# Altium schematic sheet Sheet1.SchDoc
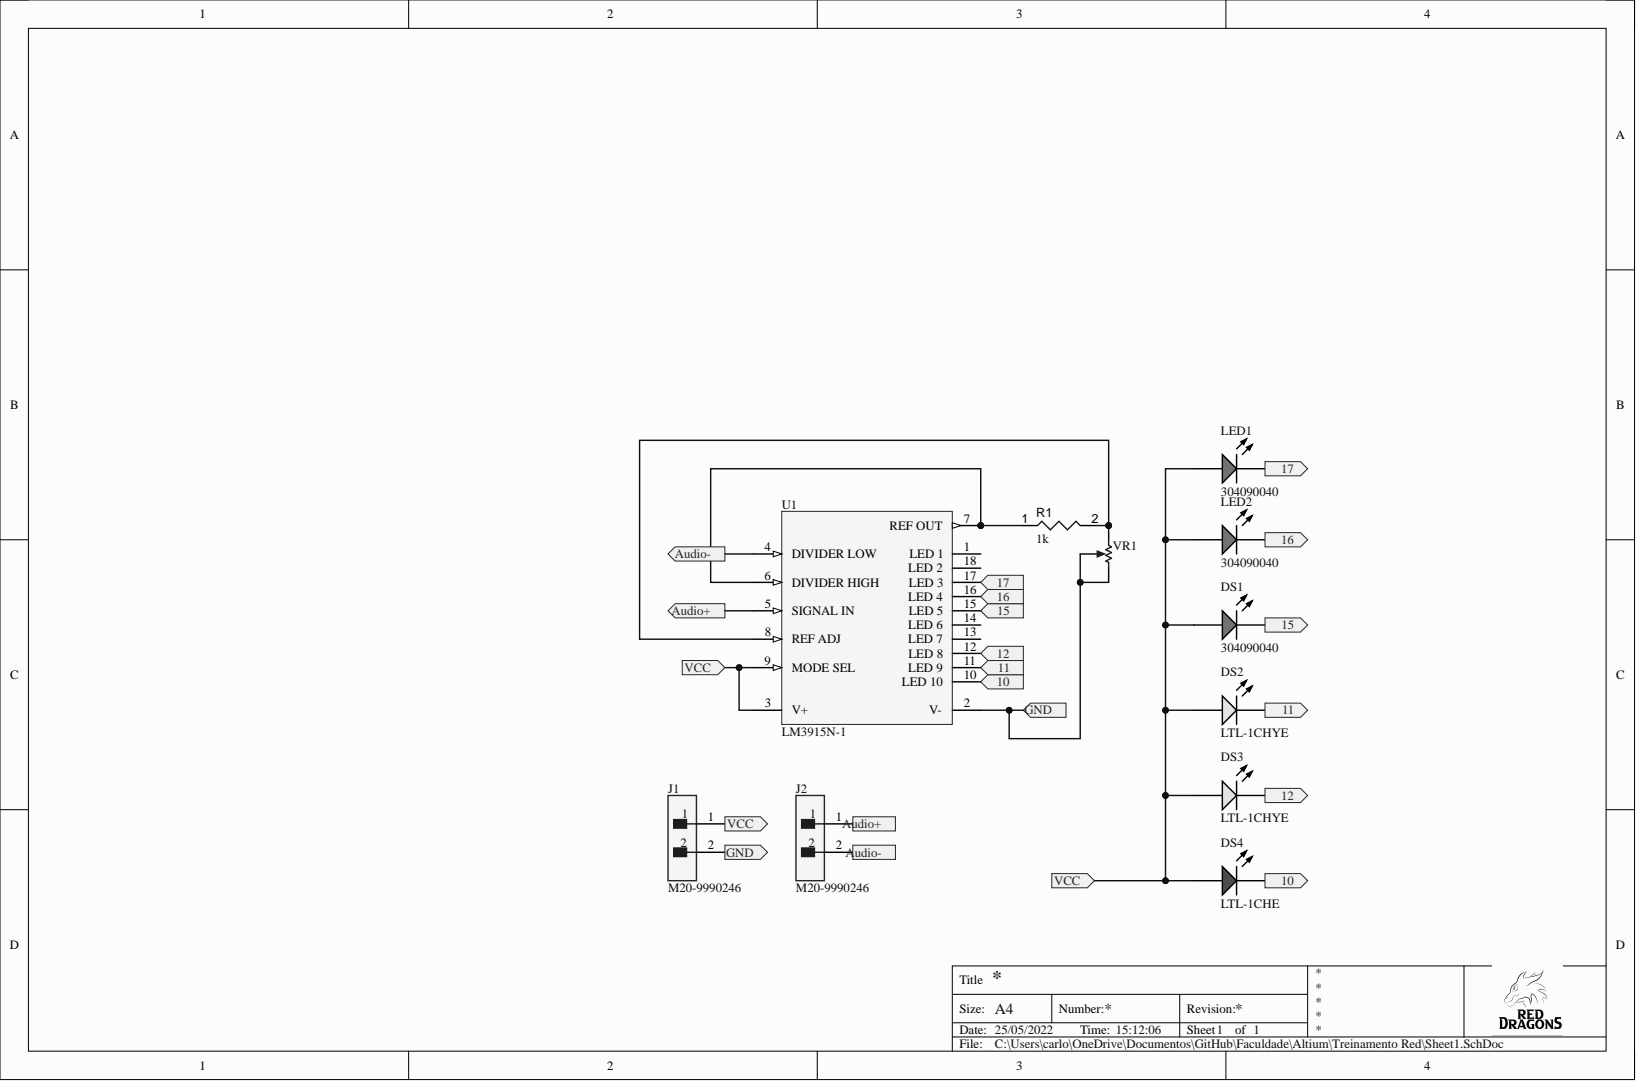
[diagram p0: Sheet1.SchDoc]

## Parts
DS1  304090040  [LED-2P-2.28-3MM]
DS2  LTL-1CHYE  [LTST-LTL-1CHYE]
DS3  LTL-1CHYE  [LTST-LTL-1CHYE]
DS4  LTL-1CHE  [INDPR60-254-520X380X650]
J1  M20-9990246  [FP-M20-9990246-MFG]
J2  M20-9990246  [FP-M20-9990246-MFG]
LED1  304090040  [LED-2P-2.28-3MM]
LED2  304090040  [LED-2P-2.28-3MM]
R1  value 1k  [RESA60-630X240]  (hidden comment: MFR-25FBF52-1K)
U1  LM3915N-1  [NFK0018A]
VR1  3362P-1-103LF  [BOUR-3362P]  (value not drawn)

## Ports
10, 11, 12, 15, 16, 17, Audio+, Audio-, GND, VCC

## Nets
10: DS4.2 U1.10(LED_10) ; port 10
11: DS2.2 U1.11(LED_9) ; port 11
12: DS3.2 U1.12(LED_8) ; port 12
15: DS1.2 U1.15(LED_5) ; port 15
16: LED2.2 U1.16(LED_4) ; port 16
17: LED1.2 U1.17(LED_3) ; port 17
GND: J1.2 U1.2(V-) VR1.2 VR1.3 ; port GND
VCC: DS1.1 DS2.1 DS3.1 DS4.1 J1.1 LED1.1 LED2.1 U1.3(V+) U1.9(MODE_SEL) ; port VCC
NetJ2_1: J2.1 U1.5(SIGNAL_IN)
NetJ2_2: J2.2 U1.4(DIVIDER_LOW)
NetR1_1: R1.1 U1.6(DIVIDER_HIGH) U1.7(REF_OUT)
NetR1_2: R1.2 U1.8(REF_ADJ) VR1.1

Not connected: U1 1(LED_1) 13(LED_7) 14(LED_6) 18(LED_2)

## Library data of the parts
DS1 LED1 LED2 = CMP-007-00006-2: Green LED, 80 mW, 20 mA, -25 to 85 degC, 2-Pin THD; Manufacturer: Shenzhen CGX; Case/Package: 304090040; Component Kind: Standard; Datasheet URL: http://datasheet.octopart.com/304090040-Chengguangxing-datasheet-62330655.pdf; Datasheet Version: Rev. 1.0, 09/2014; Manufacturer URL: http://szcgx.com/; Mounting Technology: TH; Package Description: 2-Pin Through Hole Device, Dia 3 mm, Pitch 2.28 mm; Package Reference: 304090040; RoHS Compliant: Unknown; Library Name: Ufscar - Universidade Federal de São Carlos
DS2 DS3 = CMP-007-00020-2: LED Uni-Color Yellow, 60 mW, 20 mA, -55 to 100 degC, 2-Pin THD, RoHS, Bulk; Manufacturer: Lite-On; Forward Current: 20mA; Forward Voltage: 2.1V; Lens Style: Dome; Luminous Intensity: 40mcd; Viewing Angle: 45degrees; Case/Package: Radial; Colour: Yellow; Component Kind: Standard; Datasheet URL: https://datasheet.ciiva.com/4269/s-110-ltl-1chye-4269126.pdf; Datasheet Version: Rev. B, 07/2013; Illumination Colour: Yellow; Manufacturer URL: http://www.us.liteon.com/; Max Operating Temperature: 100°C; Min Operating Temperature: -55°C; Mounting Technology: TH; Nominal Vf: 2.1V; Package Description: 2-Pin Through Hole Device, Dia 3.8 mm, Pitch 2.54 mm; Package Quantity: 1000; Package Reference: LTL-1CHYE; Packaging: Bulk; Pins: 2; RoHS Compliant: True; Library Name: Ufscar - Universidade Federal de São Carlos
DS4 = CMP-007-00018-2: LED Uni-Color Red, 100 mW, 30 mA, -55 to 100 degC, 2-Pin THD, RoHS, Bulk; Manufacturer: Lite-On; Forward Current: 20mA; Forward Voltage: 2V; Lens Style: Dome; Luminous Intensity: 12.6mcd; Viewing Angle: 60degrees; Case/Package: Radial; Colour: Red; Component Kind: Standard; Datasheet URL: https://datasheet.ciiva.com/15117/getdatasheetpartid-187746-15117985.pdf; Datasheet Version: Dec-03; Illumination Colour: Red; Manufacturer URL: http://www.us.liteon.com/; Max Operating Temperature: 100°C; Min Operating Temperature: -55°C; Mounting Technology: TH; Nominal Vf: 2V; Package Description: 2-Pin Through Hole Device, Dia 3.8 mm, Pitch 2.54 mm; Package Quantity: 1000; Package Reference: LTL-1CHE; Packaging: Bulk; Pins: 2; RoHS Compliant: True; Library Name: Ufscar - Universidade Federal de São Carlos
J1 J2 = CMP-15831-000009-1: Connector Header Through Hole 2 position 0.100" (2.54mm); Manufacturer: Harwin; Conn Gender: Male; Conn Orientation: Vertical; Current Rating: 3A; Number Of Contacts: 2; Automotive: No; Category: Conn; Component Kind: Standard; ComponentLink2Description: Datasheet; ComponentLink2URL: https://cdn.harwin.com/pdfs/M20-999.pdf; Contact Material: Tin,Nickel; Device Class L1: Connectors; Device Class L2: Headers and Wire Housings; Device Class L3: unset; Digikey Description: CONN HEADER VERT 2POS 2.54MM; Height: 8.99mm; Lead Free: Yes; Library Name: Altium Content Vault; Manufacturer Part Number: M20-9990246; Mouser Description: 2.54mm (0.1") Pitch SIL Vertical PC Tail Pin Header, 6.1mm mating pin height, tin, 2 contacts; Number Of Rows: 1; Octopart: https://octopart.com/m20-9990246-harwin-2590635; Package: M20-9990246; Pitch: 2.54mm; RoHS: TRUE; Temperature: -40°C to +105°C; Temperature Range High: +105°C; Temperature Range Low: -40°C
R1 = CMP-009-00048-4: Axial Resistor, 1 KOhm, +/- 1%, 250 mW, -55 to 155 degC, 2-Pin THD, RoHS, Bulk; Manufacturer: Yageo; Power: 250mW; Voltage Rating: 250V; Case/Package: Axial; Component Kind: Standard; Composition: CarbonFilm; Datasheet URL: http://www.yageo.com/documents/recent/Yageo%20LR_MFR_2013.pdf; Datasheet Version: Apr-13; Manufacturer URL: http://www.yageo.com/; Max Operating Temperature: 155°C; Min Operating Temperature: -55°C; Mounting Technology: TH; Package Description: 2-Pin Axial Lead, Dia 2.4 mm, Body Length 6.3 mm; Package Quantity: 200; Package Reference: MFR-25; Packaging: Bulk; RoHS Compliant: True; Tolerance: 1%; Library Name: Ufscar - Universidade Federal de São Carlos
U1 = CMP-0058-00005-3: Dot/Bar Display Driver, 18-pin MDIP; Manufacturer: Texas Instruments; CompType: Audio IC; PackageTopMarking: NSUZXYYTTE#*LM3915N-1; PowerWise: N; Code_JEDEC: MS-001-AC; Component Kind: Standard; ComponentLink1Description: Datasheet URL; ComponentLink1URL: http://www.ti.com/general/docs/lit/getliterature.tsp?genericPartNumber=LM3915&fileType=pdf; Library Name: Altium Content Vault; PackageDescription: MDIP, .900 x .250 x .155in, 18 Lead, .100in Pitch; PackageReference: NFK0018A; PinCount: 18; RoHS: N
VR1 = CMP-009-00039-2: Square Trimpot(R) Trimming Potentiometer, 10 KOhm, 300 V, -55 to 125 degC, 3-Pin THD, RoHS, Tube; Manufacturer: Bourns; Power: 500mW; Resistance: 10k; Tolerance: 10%; Voltage Rating: 300V; Case/Package: 3326P; Component Kind: Standard; Composition: Potentiometer; Datasheet URL: http://www.bourns.com/docs/Product-Datasheets/3362.pdf; Datasheet Version: May-16; Lead Pitch: 2.54mm; Manufacturer URL: http://www.bourns.com/; Max Operating Temperature: 125°C; Min Operating Temperature: -55°C; Mounting Technology: TH; Package Description: 3-Pin Through Hole Device, Body 6.6 x 6.99 mm, Pitch 2.54 mm; Package Quantity: 250; Package Reference: 3326P; Packaging: Rail/Tube; Pins: 3; RoHS Compliant: True; Library Name: Ufscar - Universidade Federal de São Carlos
